# Altium schematic sheet P12_M1_ONLY.SchDoc(P12_M1_ONLY)
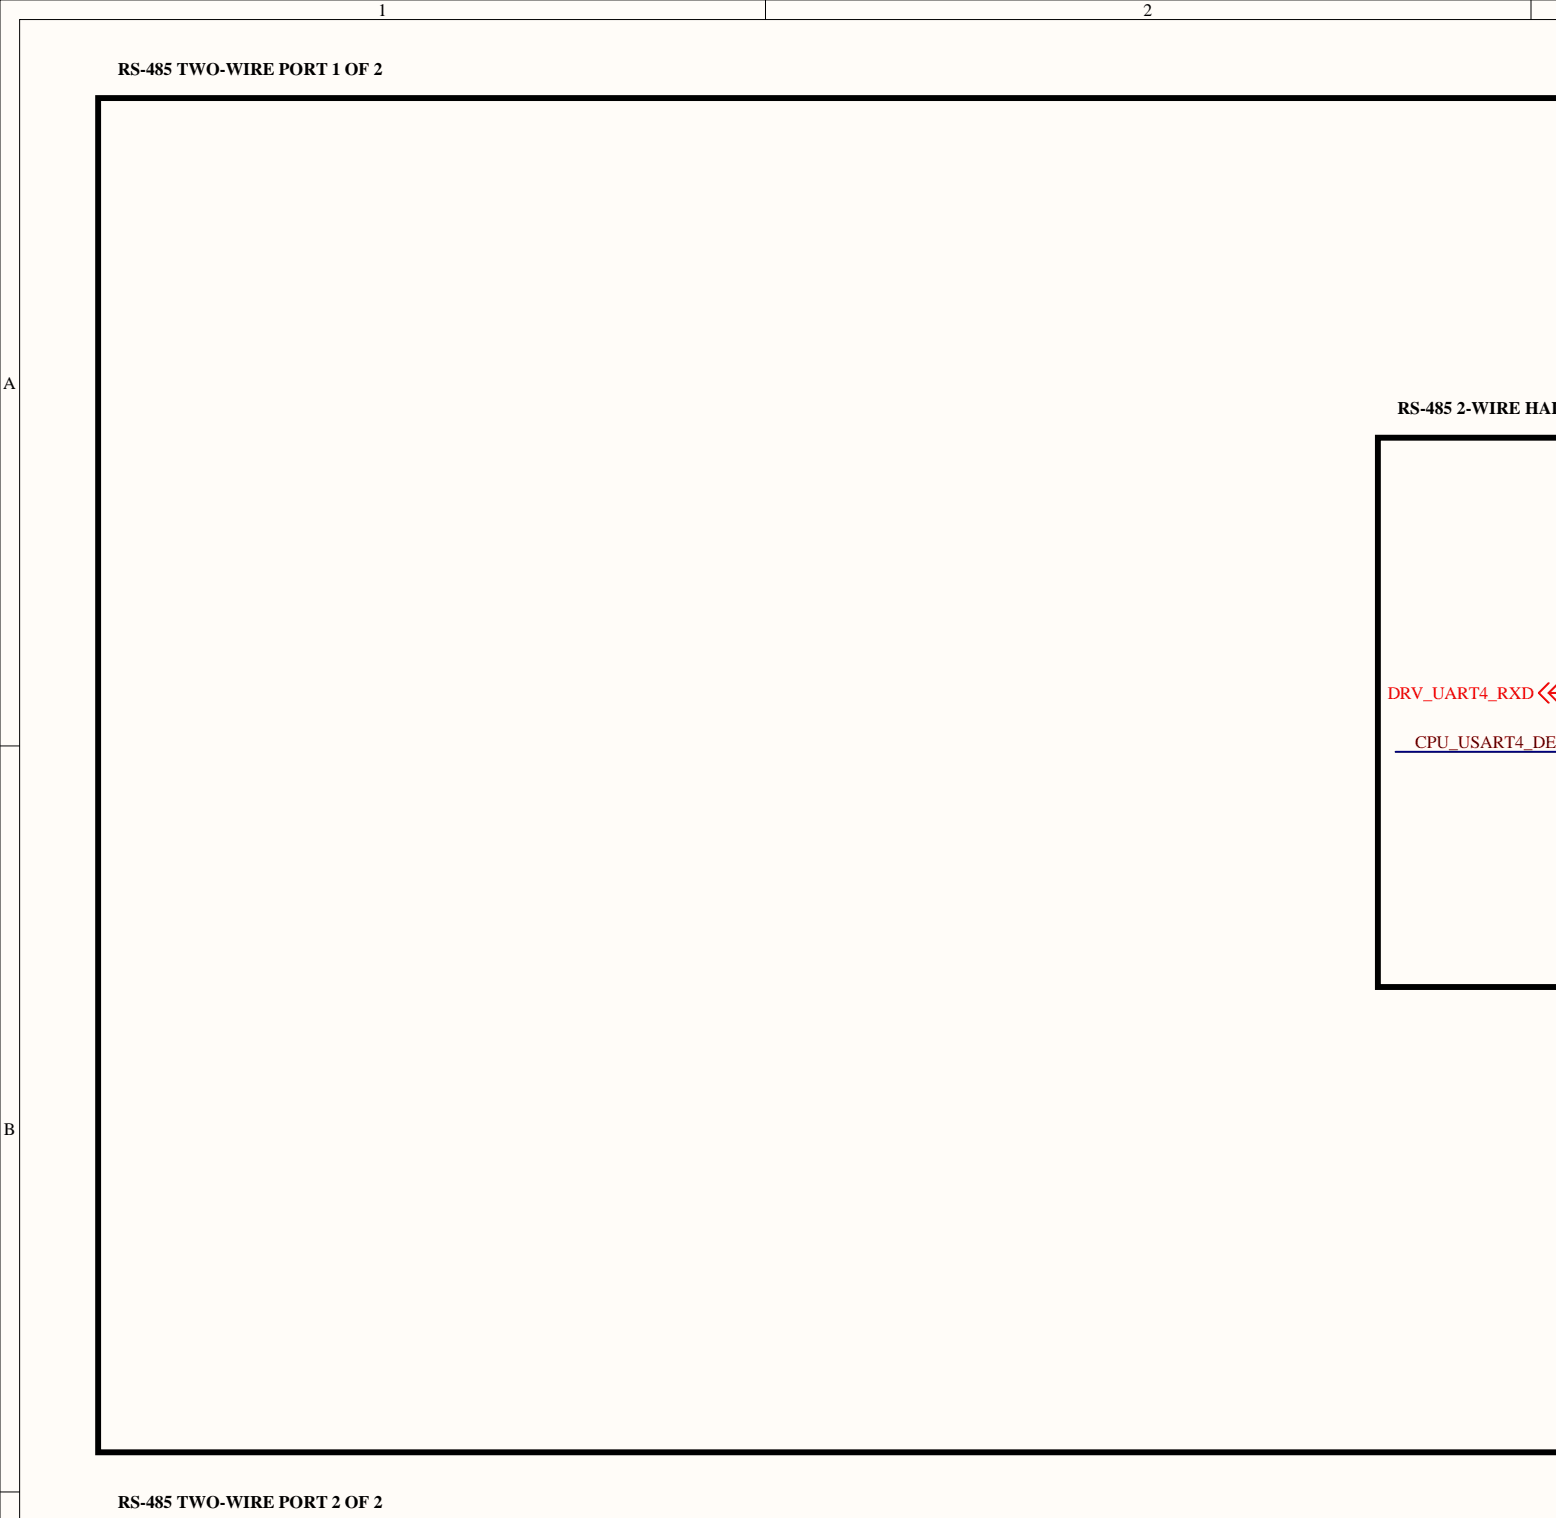
[diagram p0: P12_M1_ONLY.SchDoc, top left]
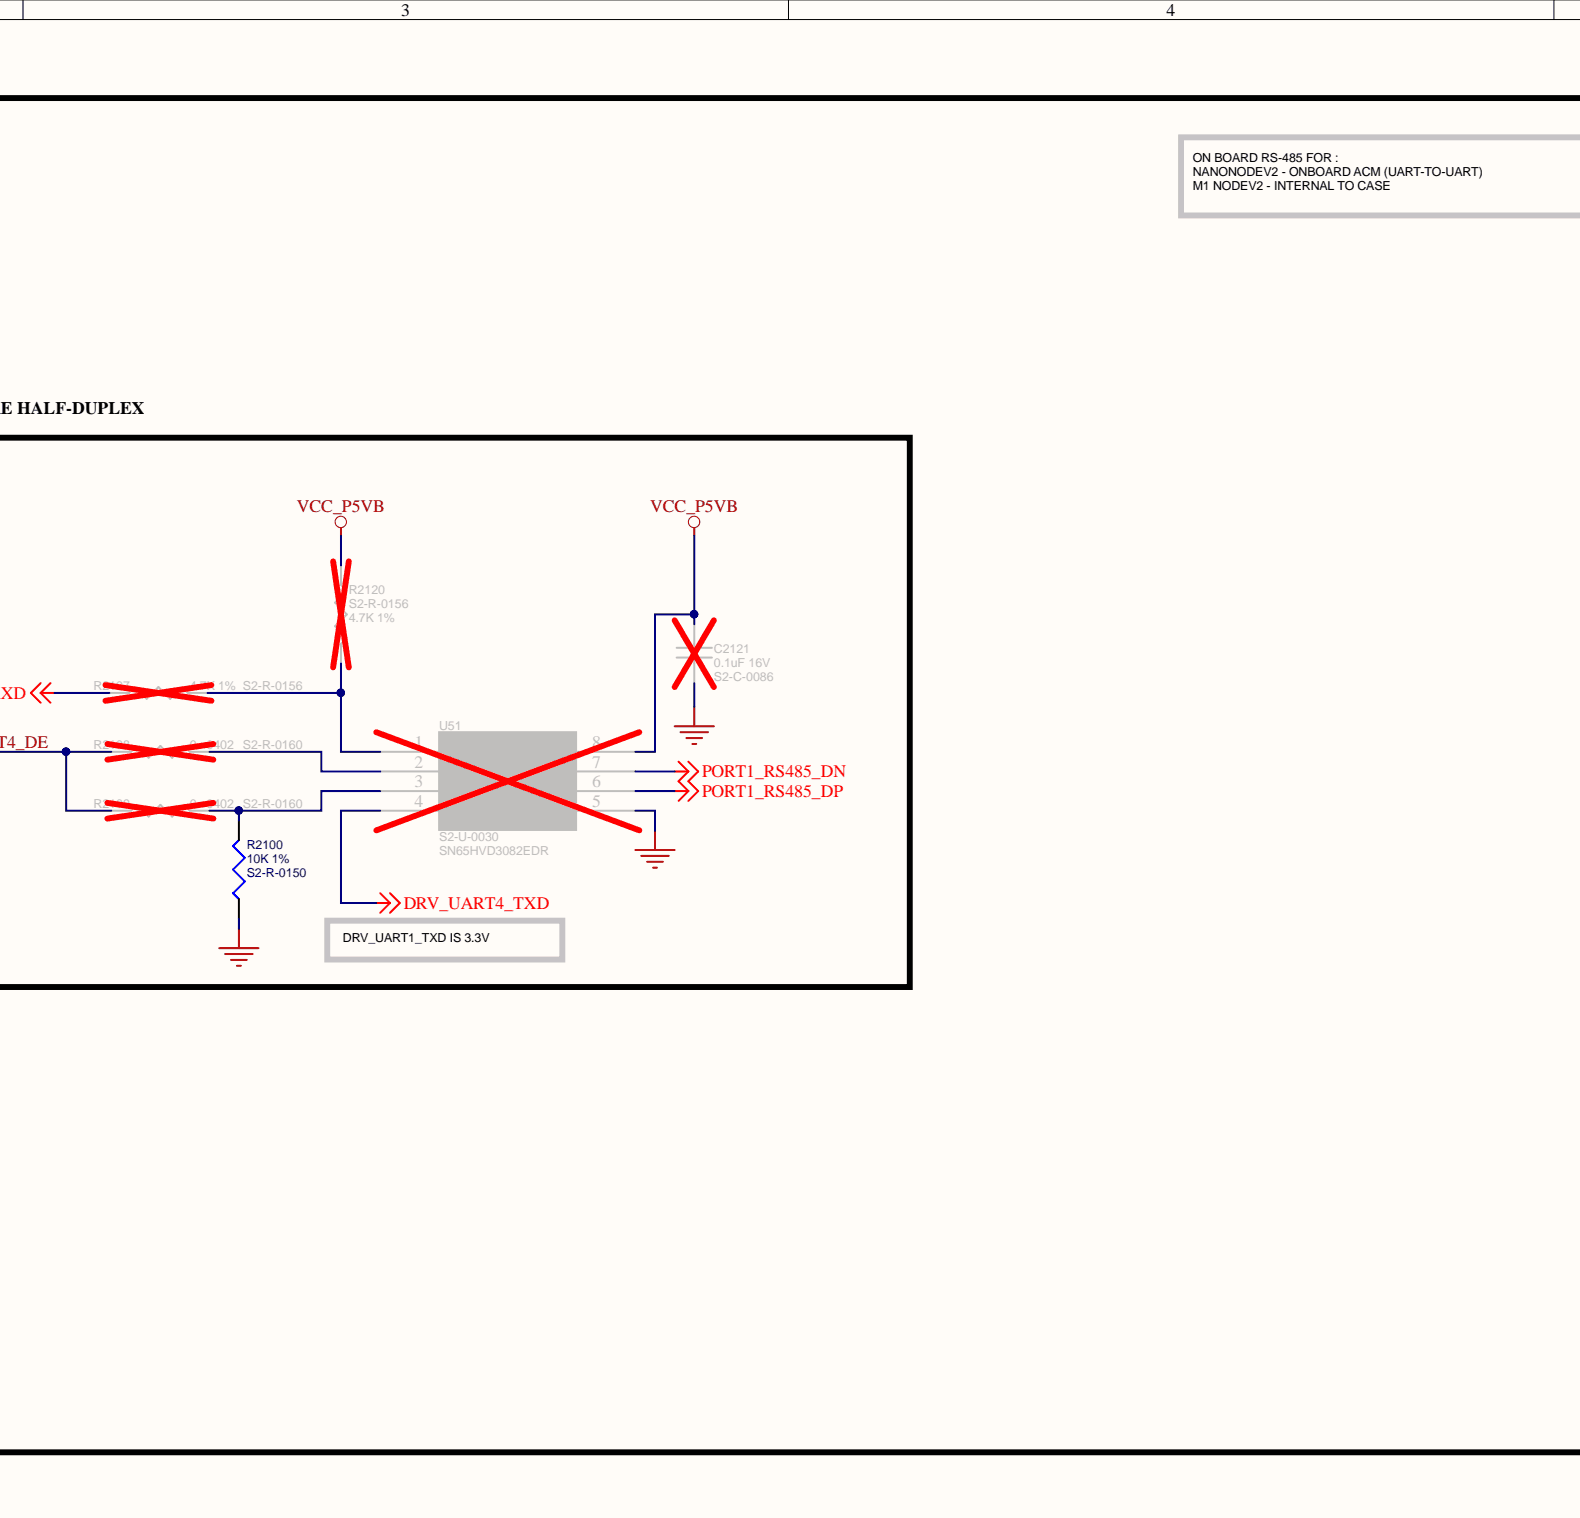
[diagram p1: P12_M1_ONLY.SchDoc, top centre]
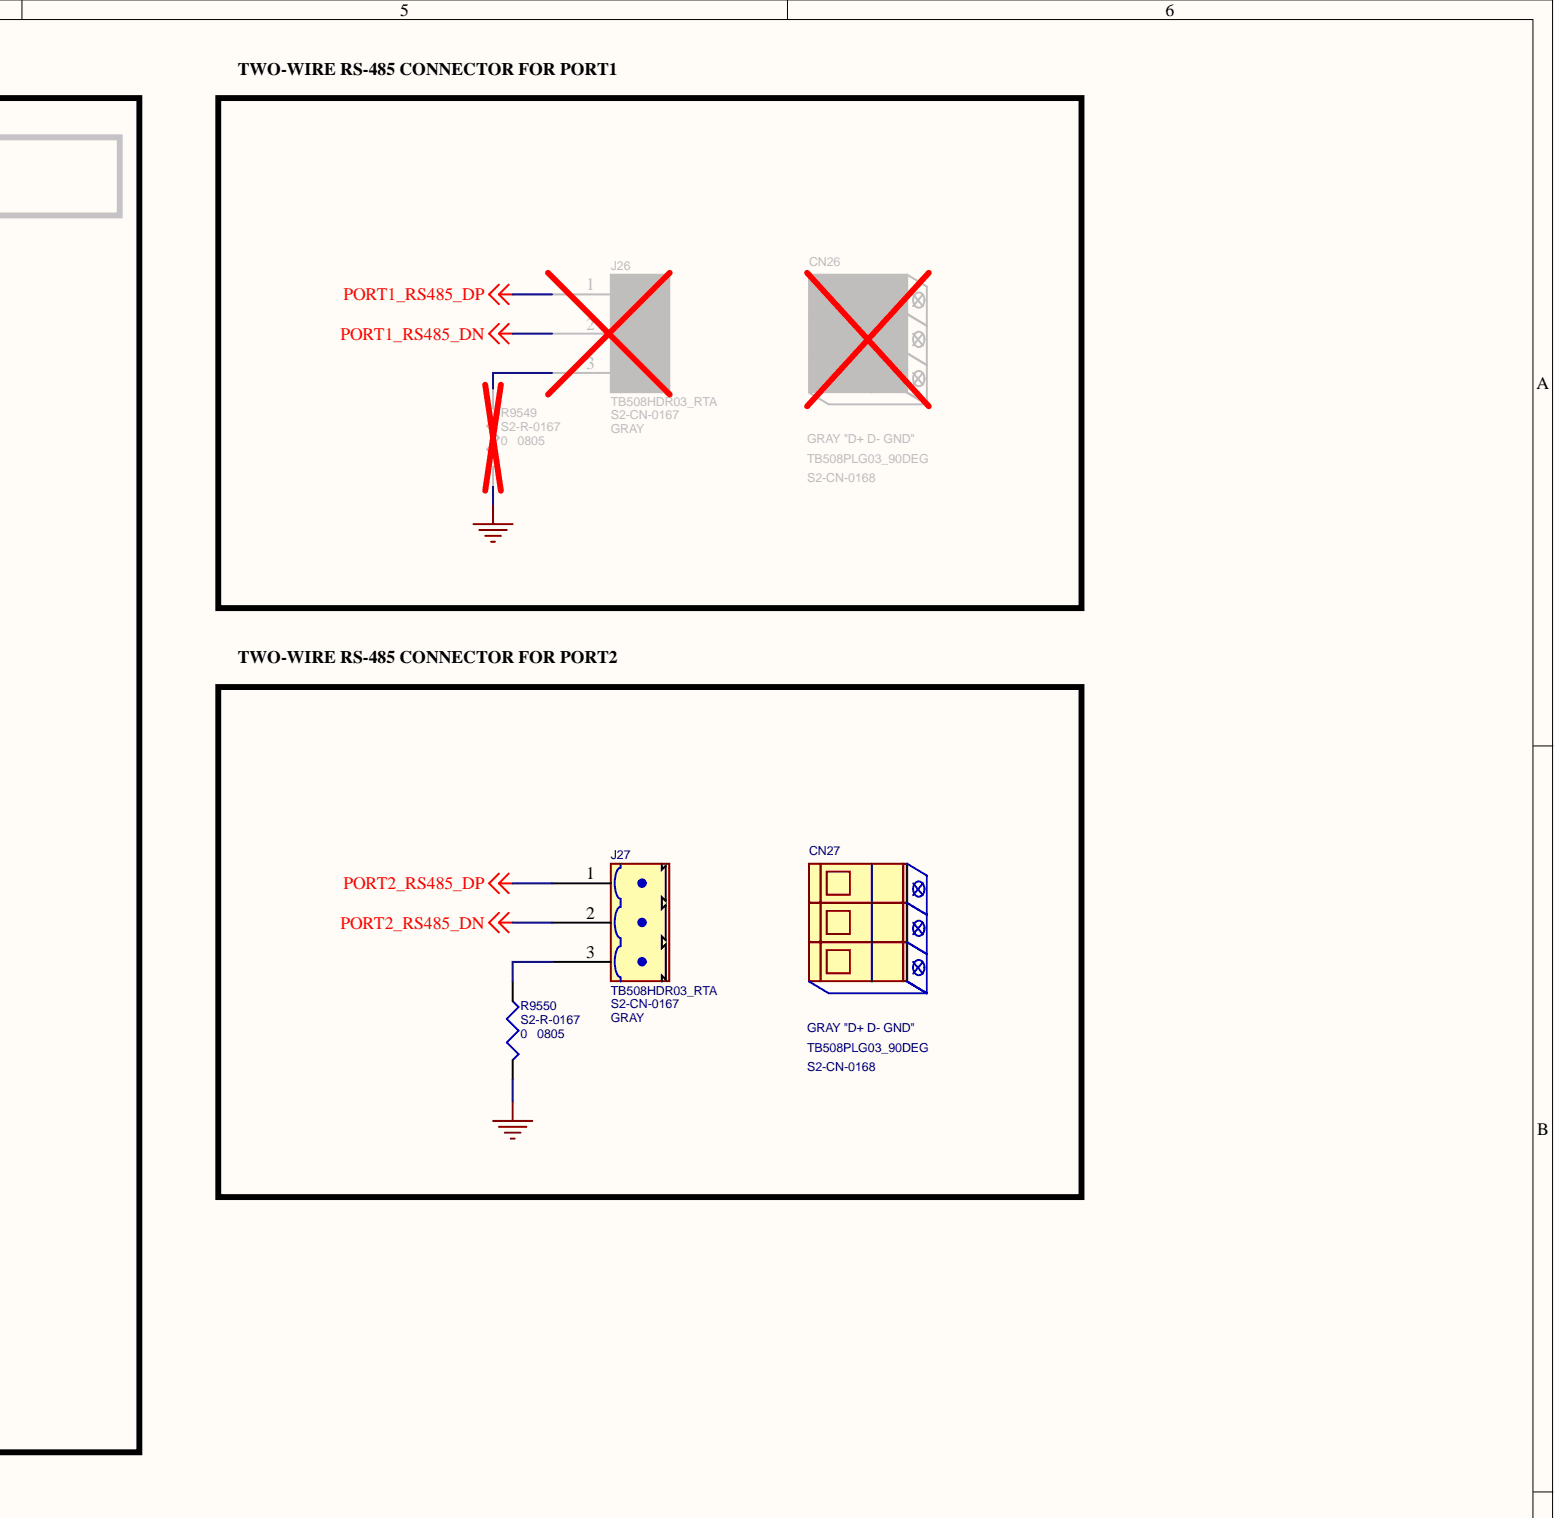
[diagram p2: P12_M1_ONLY.SchDoc, top right]
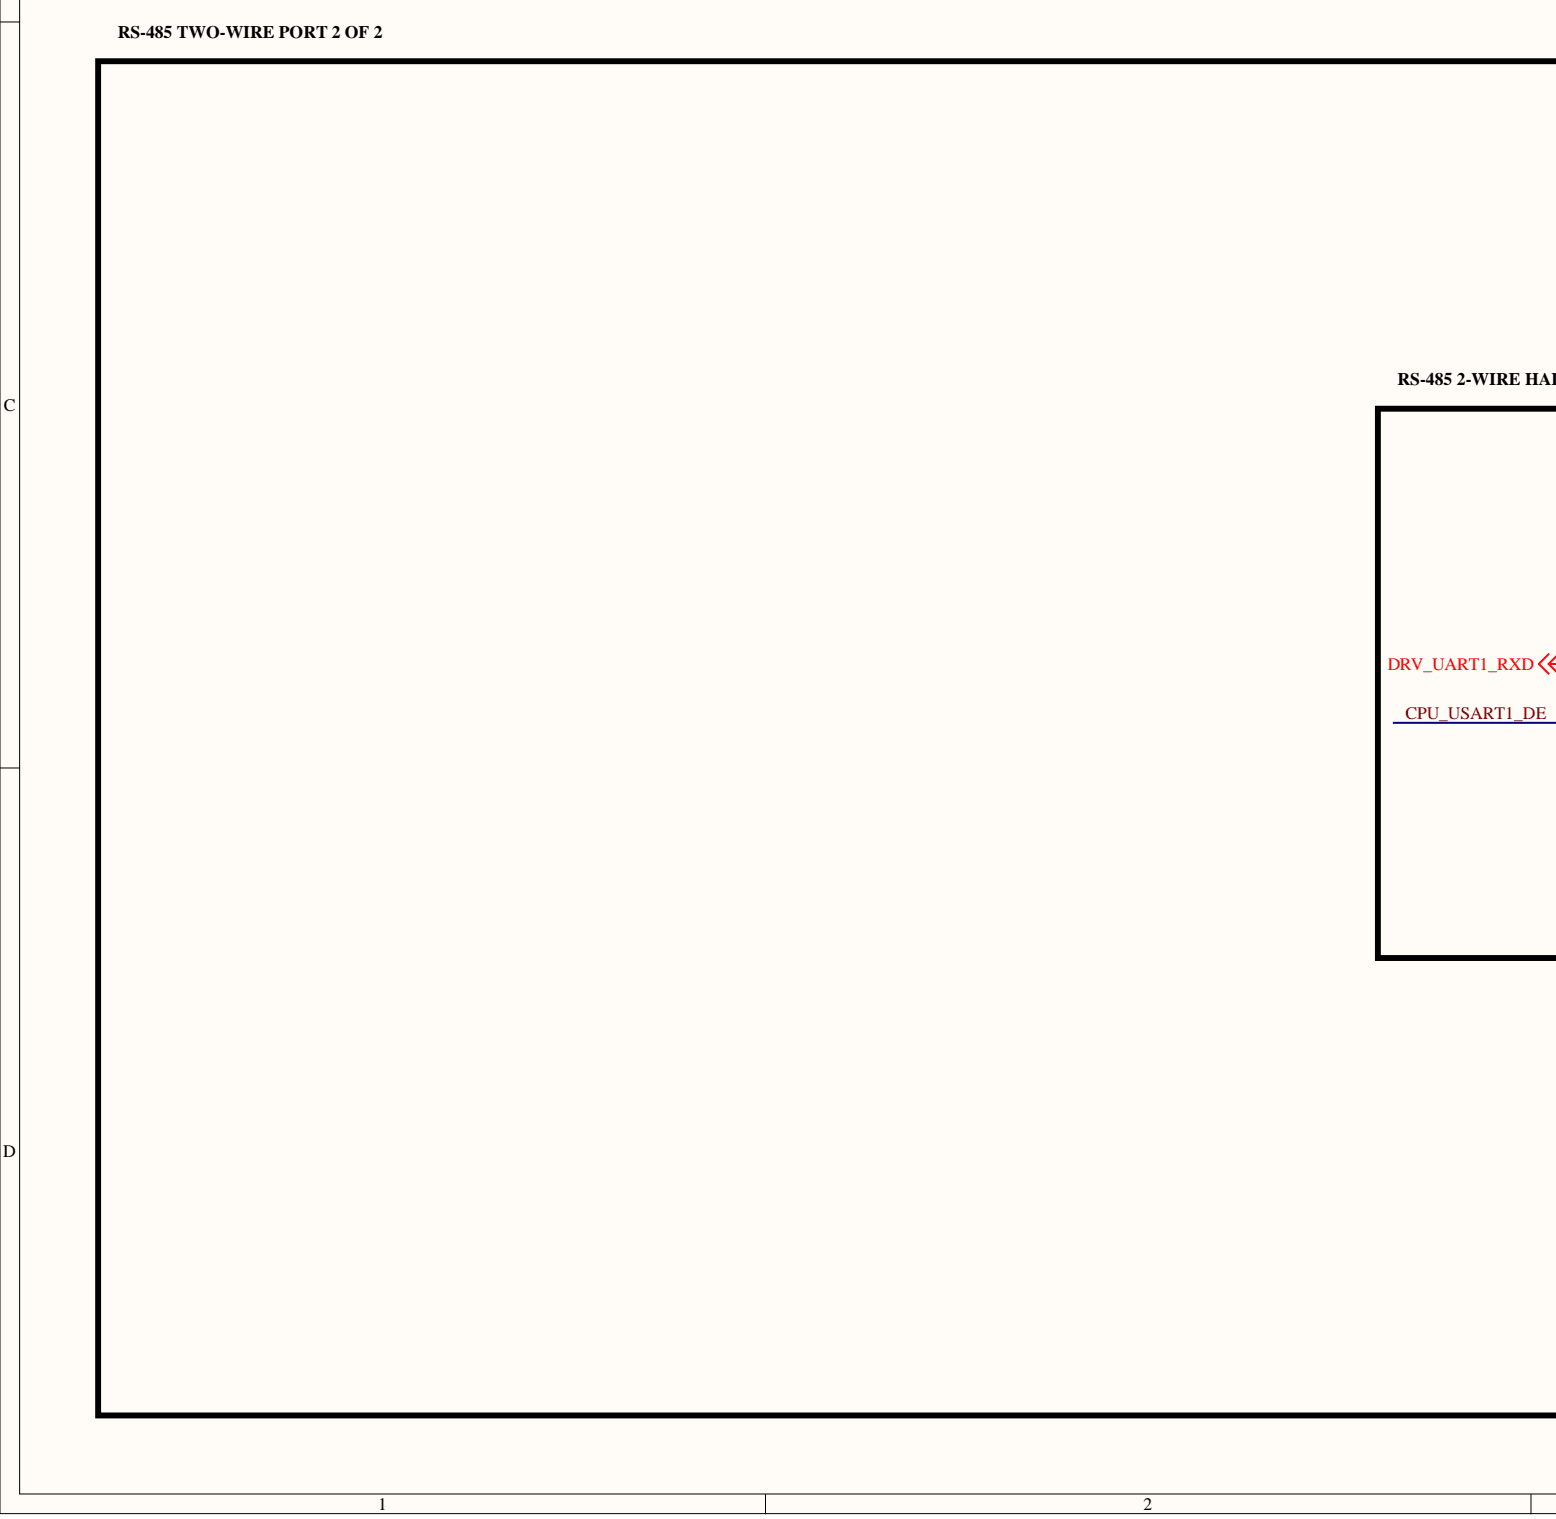
[diagram p3: P12_M1_ONLY.SchDoc, bottom left]
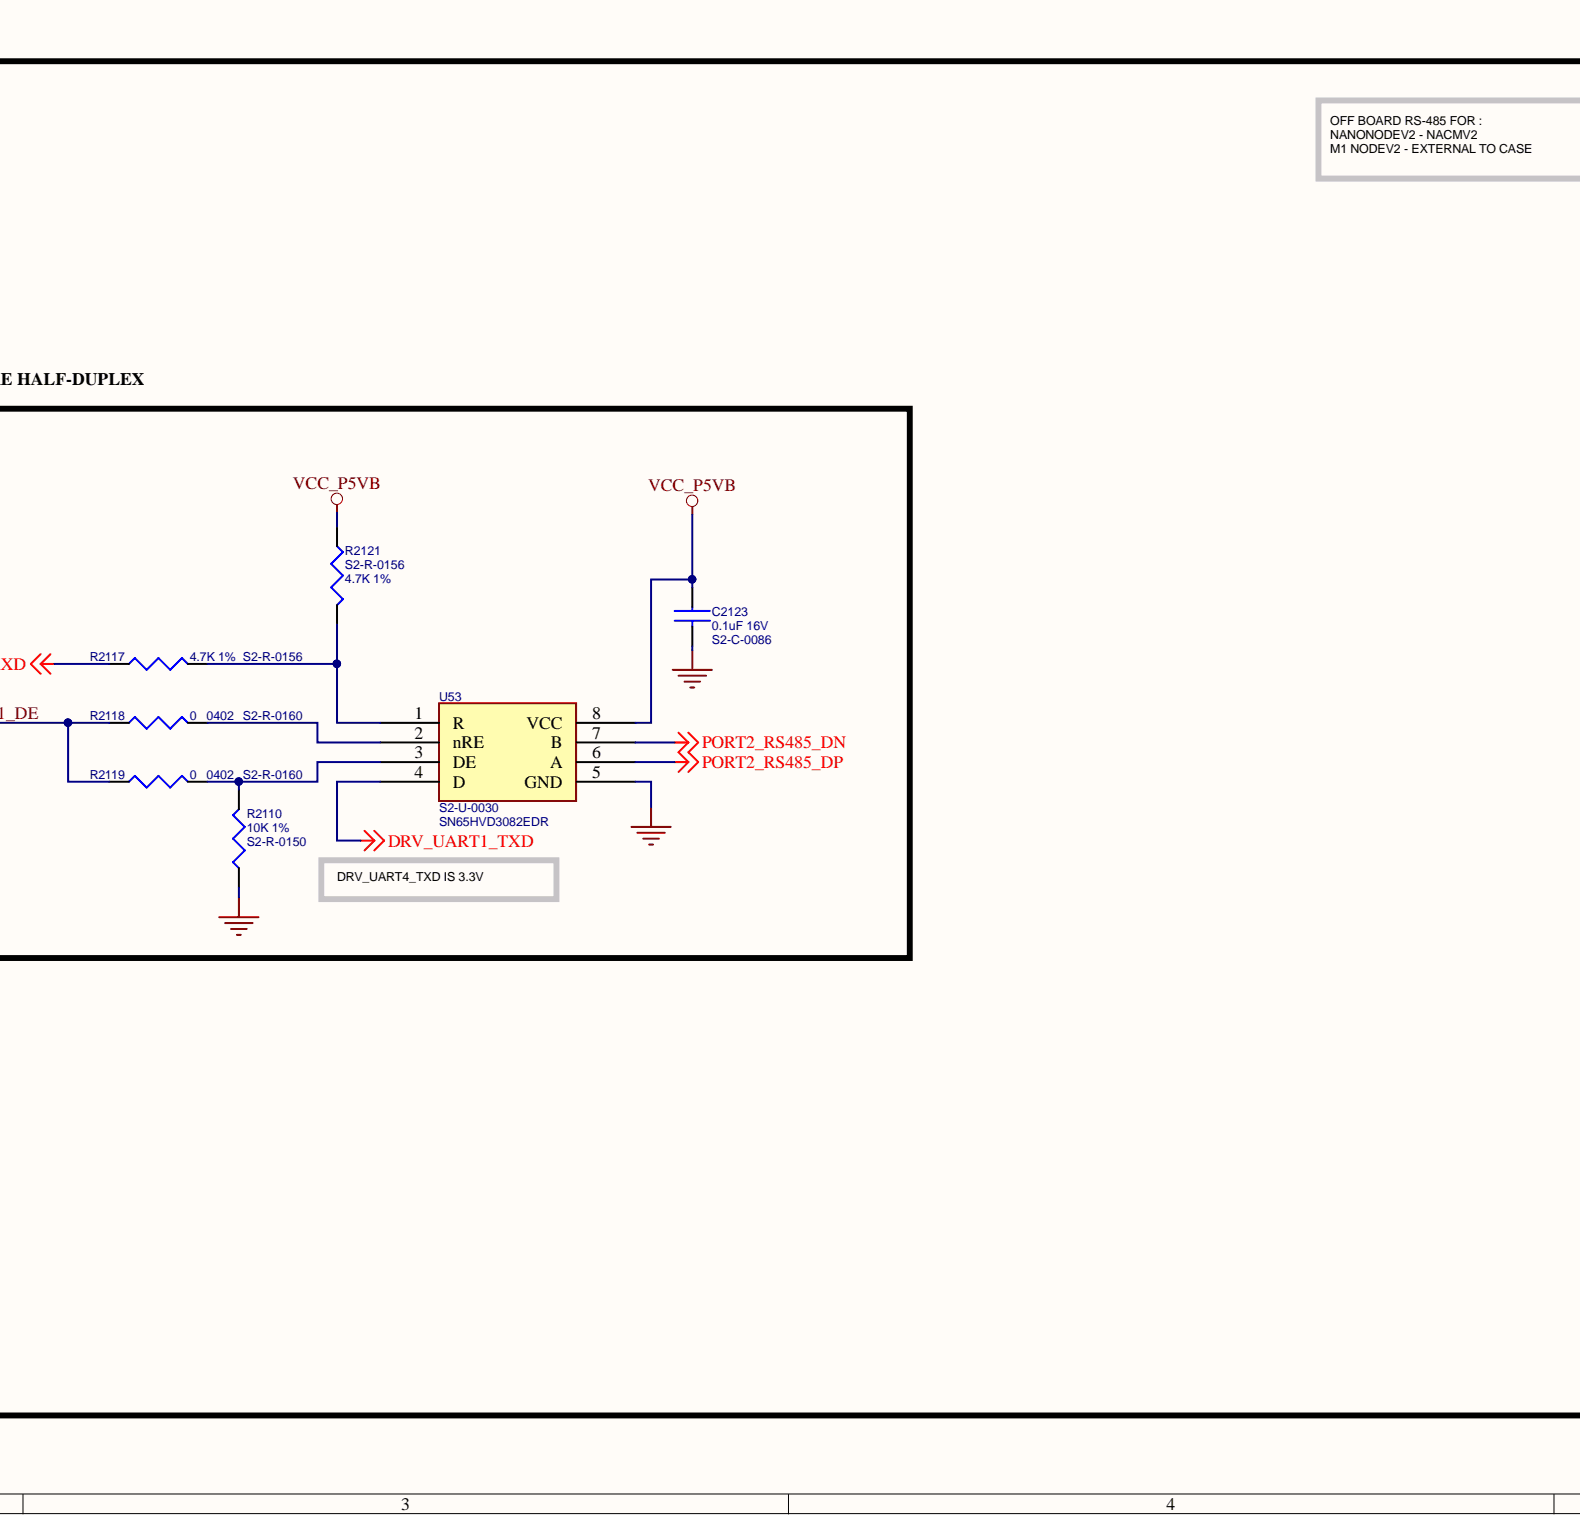
[diagram p4: P12_M1_ONLY.SchDoc, bottom centre]
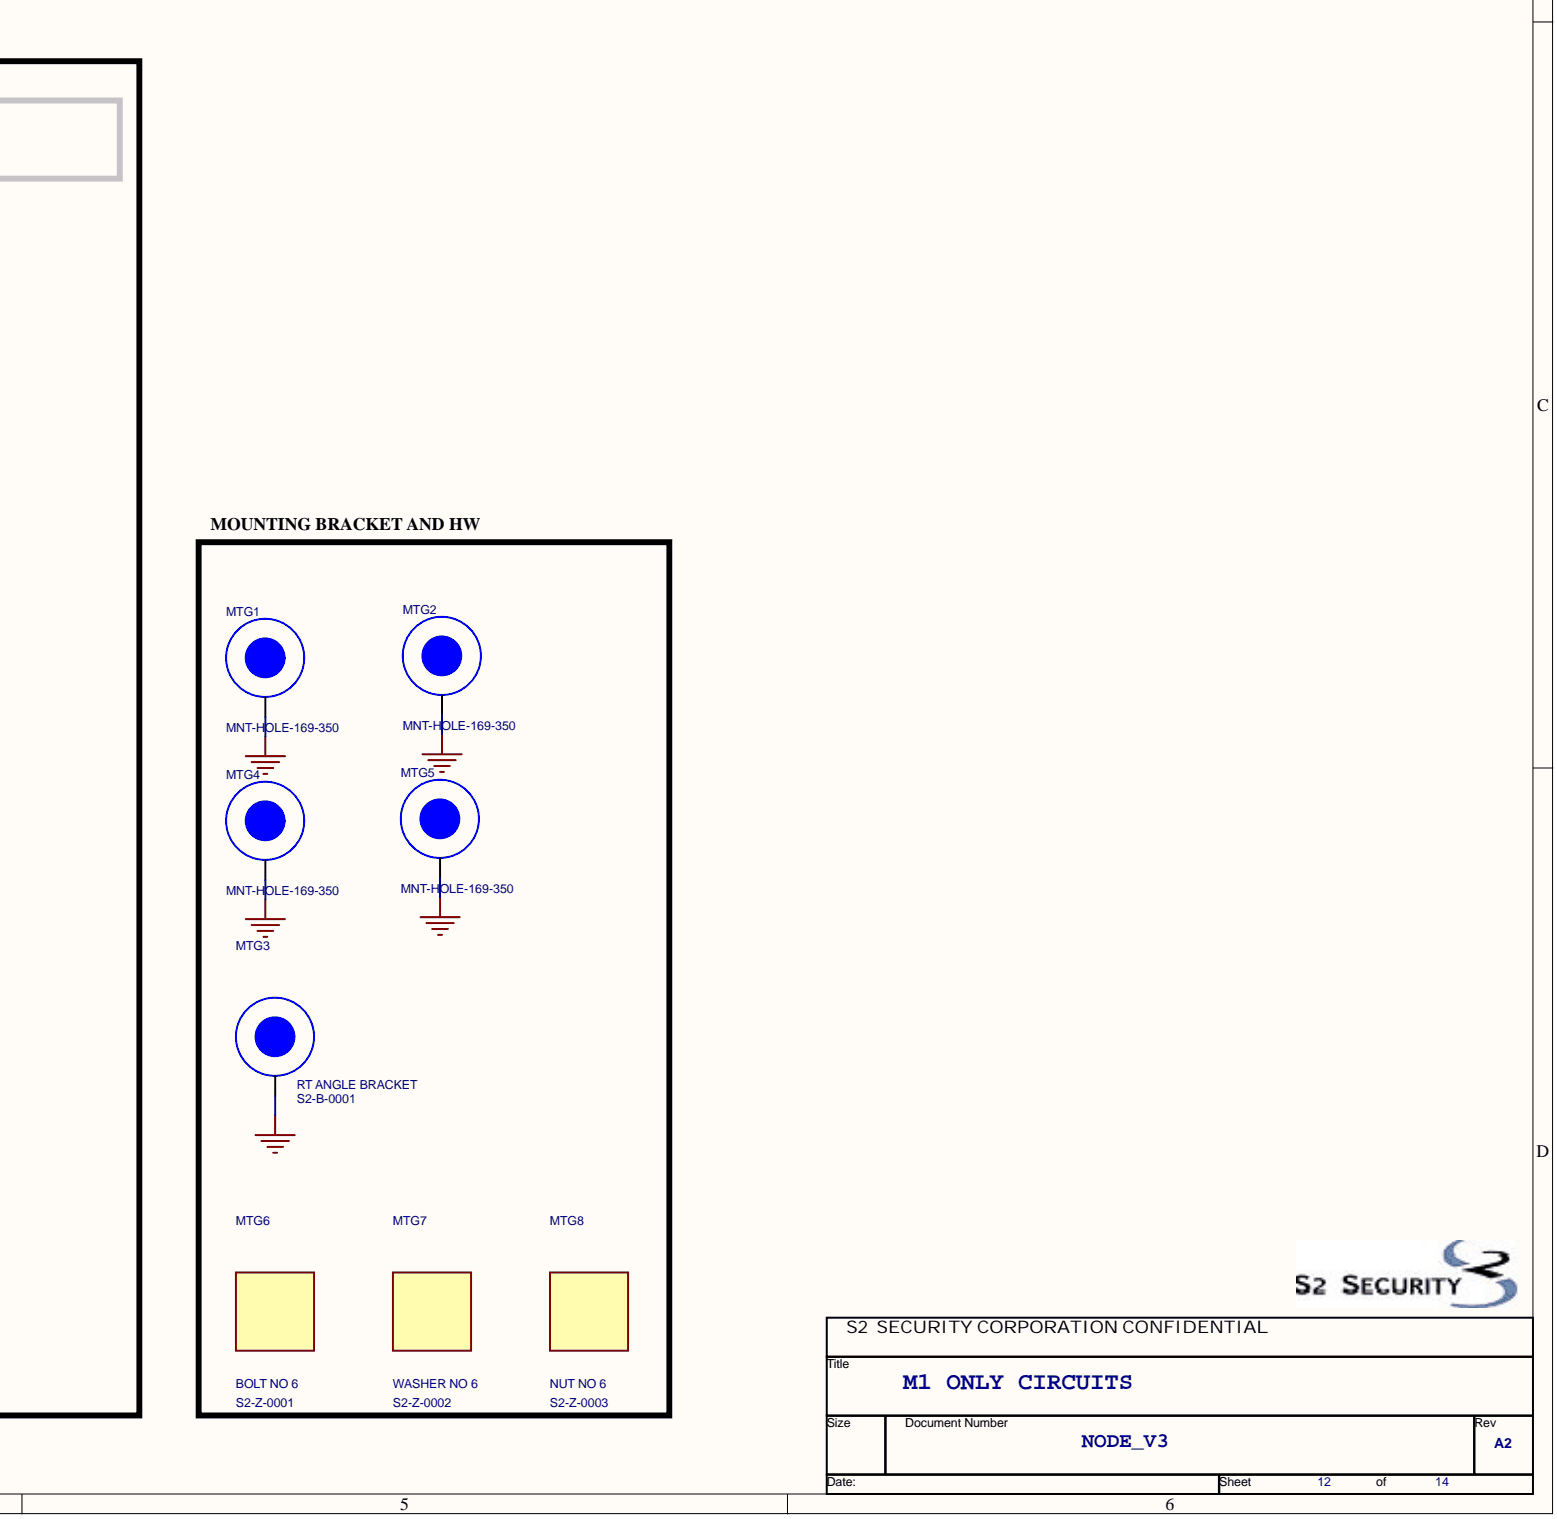
[diagram p5: P12_M1_ONLY.SchDoc, bottom right]

## Parts
C2121  S2_PN: S2-C-0086  DESC: 0.1uF 16V  [CAPC1005X55N]  (hidden comment: C_0U1_16V_0402)
C2123  S2_PN: S2-C-0086  DESC: 0.1uF 16V  [CAPC1005X55N]  (hidden comment: C_0U1_16V_0402)
CN26  DESC: TB508PLG03_90DEG  ATTR2: GRAY "D+ D- GND"  [N/A]  (hidden comment: CN_5MM08_03PLUG_90DEG_GRY_485)
CN27  S2_PN: S2-CN-0168  DESC: TB508PLG03_90DEG  ATTR2: GRAY "D+ D- GND"  [N/A]  (hidden comment: CN_5MM08_03PLUG_90DEG_GRY_485)
J26  S2_PN: S2-CN-0167  DESC: TB508HDR03_RTA  ATTR2: GRAY  [Conn_5433671]  (hidden comment: CN_5MM08_03POS_HEADER_RTA_GRAY)
J27  S2_PN: S2-CN-0167  DESC: TB508HDR03_RTA  ATTR2: GRAY  [Conn_5433671]  (hidden comment: CN_5MM08_03POS_HEADER_RTA_GRAY)
MTG1  MTG_MNT_HOLE_169_350  [MNT-HOLE-169-350]  (value not drawn)
MTG2  MTG_MNT_HOLE_169_350  [MNT-HOLE-169-350]  (value not drawn)
MTG3  S2_PN: S2-B-0001  DESC: RT ANGLE BRACKET  [BRACKET$5C$KEYSTONE$5C$618]  (hidden comment: B_RT_ANGLE_BRACKET)
MTG4  MTG_MNT_HOLE_169_350  [MNT-HOLE-169-350]  (value not drawn)
MTG5  MTG_MNT_HOLE_169_350  [MNT-HOLE-169-350]  (value not drawn)
MTG6  S2_PN: S2-Z-0001  PKG: BOLT  DESC: BOLT NO 6  [N/A]  (hidden comment: Z_BOLT_NO6_32)
MTG7  S2_PN: S2-Z-0002  PKG: WASHER  DESC: WASHER NO 6  [N/A]  (hidden comment: Z_WASHER_NO6)
MTG8  S2_PN: S2-Z-0003  PKG: NUT  DESC: NUT NO 6  [N/A]  (hidden comment: Z_NUT_NO6_32)
R2100  S2_PN: S2-R-0150  DESC: 10K 1%  [RESC1005X40N]  (hidden comment: R_10K_0402)
R2107  S2_PN: S2-R-0156  DESC: 4.7K 1%  [RESC1005X40N]  (hidden comment: R_4K7_0402)
R2108  S2_PN: S2-R-0160  DESC: 0   0402  [RESC1005X40N]  (hidden comment: R_0_0402)
R2109  DESC: 0   0402  [RESC1005X40N]  (hidden comment: R_0_0402)
R2110  S2_PN: S2-R-0150  DESC: 10K 1%  [RESC1005X40N]  (hidden comment: R_10K_0402)
R2117  S2_PN: S2-R-0156  DESC: 4.7K 1%  [RESC1005X40N]  (hidden comment: R_4K7_0402)
R2118  S2_PN: S2-R-0160  DESC: 0   0402  [RESC1005X40N]  (hidden comment: R_0_0402)
R2119  DESC: 0   0402  [RESC1005X40N]  (hidden comment: R_0_0402)
R2120  S2_PN: S2-R-0156  DESC: 4.7K 1%  [RESC1005X40N]  (hidden comment: R_4K7_0402)
R2121  S2_PN: S2-R-0156  DESC: 4.7K 1%  [RESC1005X40N]  (hidden comment: R_4K7_0402)
R9549  S2_PN: S2-R-0167  DESC: 0   0805  [RESC2012X50N]  (hidden comment: R_0_0805)
R9550  S2_PN: S2-R-0167  DESC: 0   0805  [RESC2012X50N]  (hidden comment: R_0_0805)
U51  S2_PN: S2-U-0030  DESC: SN65HVD3082EDR  [SOIC127P600X175-8N]  (hidden comment: U_RS485_HALF_DUPLEX)
U53  S2_PN: S2-U-0030  DESC: SN65HVD3082EDR  [SOIC127P600X175-8N]  (hidden comment: U_RS485_HALF_DUPLEX)

## Nets
DRV_UART1_RXD: R2117.1
DRV_UART1_TXD: U53.4(D)
DRV_UART4_RXD: R2107.1
DRV_UART4_TXD: U51.4(D)
GND: C2121.2 C2123.2 MTG1.1 MTG2.1 MTG3.1 MTG4.1 MTG5.1 R2100.2 R2110.2 R9549.2 R9550.2 U51.5(GND) U53.5(GND)
PORT1_RS485_DN: J26.2 U51.7(B)
PORT1_RS485_DP: J26.1 U51.6(A)
PORT2_RS485_DN: J27.2 U53.7(B)
PORT2_RS485_DP: J27.1 U53.6(A)
VCC_P5VB: C2121.1 C2123.1 R2120.1 R2121.1 U51.8(VCC) U53.8(VCC)
CPU_USART1_DE: R2118.1 R2119.1
CPU_USART4_DE: R2108.1 R2109.1
NetJ26_3: J26.3 R9549.1
NetJ27_3: J27.3 R9550.1
NetR2100_1: R2100.1 R2109.2 U51.3(DE)
NetR2107_2: R2107.2 R2120.2 U51.1(R)
NetR2108_2: R2108.2 U51.2(nRE)
NetR2110_1: R2110.1 R2119.2 U53.3(DE)
NetR2117_2: R2117.2 R2121.2 U53.1(R)
NetR2118_2: R2118.2 U53.2(nRE)

## Library data of the parts
C2121 C2123 = C_0U1_16V_0402: PKG: CC0402IPC; ATTR: X7R 10%; Library Name: NODE_V2_M1.SCHLIB; Component Kind: Standard
CN26 = CN_5MM08_03PLUG_90DEG_GRY_485: S2_PN: S2-CN-0168; PKG: TB508PLUG03_180DEG; ATTR3: BCP-508- 3 GY; ATTR: PLUG TERM GRAY 5.08MM 3POS 180DEG BLACK; Component Kind: Standard
CN27 = CN_5MM08_03PLUG_90DEG_GRY_485: PKG: TB508PLUG03_180DEG; ATTR3: BCP-508- 3 GY; ATTR: PLUG TERM GRAY 5.08MM 3POS 180DEG BLACK; Component Kind: Standard
J26 J27 = CN_5MM08_03POS_HEADER_RTA_GRAY: PKG: TB508HDR02_RTA; ATTR3: BCH-508HS- 3 GY; ATTR: HEADER TERM BLK 5.08MM 2POS RTA GRAY; Library Name: NODE_V2_M1.SCHLIB; Component Kind: Standard
MTG1 MTG2 MTG4 MTG5 = MTG_MNT_HOLE_169_350: S2_PN: S2-MTG-0001; ATTR: Number 3 Plated Mounting Hole 169 350; PKG: MNT-HOLE-169-350; Library Name: NODE_V2_M1.SCHLIB; DNP: DNP; DESC: MNT-HOLE-169-350; Component Kind: Standard
MTG3 = B_RT_ANGLE_BRACKET: PKG: BRACK-RA-TH; ATTR: Bracket, Right Angle, 0.618" X 0.618"; Component Kind: Standard
MTG6 = Z_BOLT_NO6_32: ATTR: Bolt, #6-32 x 0.25", Phil Pan, SST (RoHS); Component Kind: Standard
MTG7 = Z_WASHER_NO6: ATTR: Washer, #6, SST, Internal Tooth, Lock; Component Kind: Standard
MTG8 = Z_NUT_NO6_32: ATTR: Nut, #6-32 Stainless (RoHS); Component Kind: Standard
R2100 R2110 = R_10K_0402: PKG: CC0402IPC; ATTR: 1/16W; Library Name: NODE_V2_M1.SCHLIB; Component Kind: Standard
R2107 R2117 R2120 R2121 = R_4K7_0402: PKG: CC0402IPC; ATTR: 1/16W; Library Name: NODE_V2_M1.SCHLIB; Component Kind: Standard
R2108 R2118 = R_0_0402: PKG: CC0402IPC; ATTR: 1/16W  50mohm MAX; Library Name: NODE_V2_M1.SCHLIB; Component Kind: Standard
R2109 R2119 = R_0_0402: S2_PN: S2-R-0160; PKG: CC0402IPC; ATTR: 1/16W  50mohm MAX; Library Name: NODE_V2_M1.SCHLIB; Component Kind: Standard
R9549 R9550 = R_0_0805: PKG: CC0805IPC; ATTR: 1/10W 50mohm MAX; Library Name: NODE_V2_M1.SCHLIB; Component Kind: Standard
U51 U53 = U_RS485_HALF_DUPLEX: PKG: SOIC-8; ATTR: IC LO-PWR RS-485 TXRX HALF DUPLEX TRIPLE FAILSAFE; Library Name: NODE_V2_M1.SCHLIB; Component Kind: Standard
